# Altium schematic sheet OTHER_PARTS.SchDoc(OTHER_PARTS)
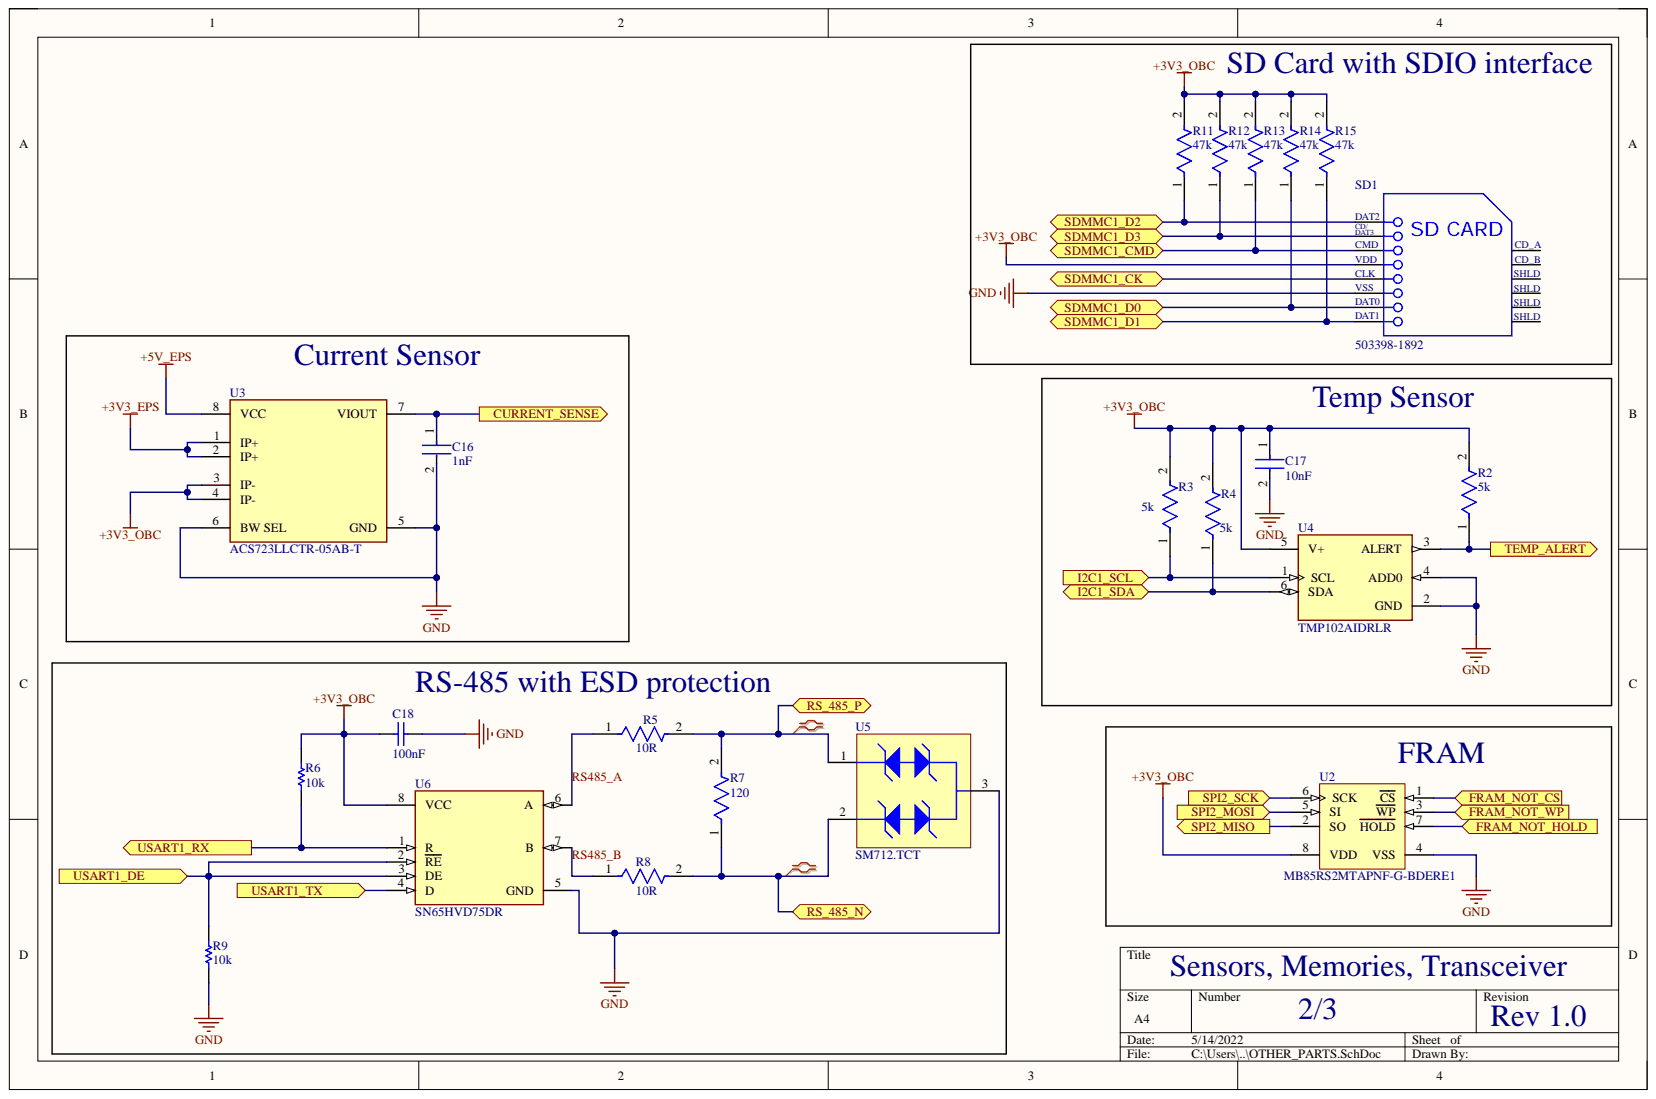
[diagram p0: OTHER_PARTS.SchDoc]

## Parts
C16  1nF  [FP-CC0402-MFG]
C17  10nF  [FP-CL165-IPC_C]
C18  100nF  [SAMS-C0603-2_M]
R2  5k  [FP-MCR01-MFG]
R3  5k  [FP-MCR01-MFG]
R4  5k  [FP-MCR01-MFG]
R5  10R  [FP-SR0805-MFG]
R6  10k  [RESC1608X55X25ML10T15]
R7  120  [FP-AC1206-MFG]
R8  10R  [FP-SR0805-MFG]
R9  10k  [RESC1608X55X25ML10T15]
R11  47k  [FP-MCR01-IPC_C]
R12  47k  [FP-MCR01-IPC_C]
R13  47k  [FP-MCR01-IPC_C]
R14  47k  [FP-MCR01-IPC_C]
R15  47k  [FP-MCR01-IPC_C]
SD1  503398-1892  [MOLX-503398-1892_V]
U2  MB85RS2MTAPNF-G-BDERE1  [CYP-S08.15_M]
U3  ACS723LLCTR-05AB-T  [FP-LC-8-MFG]
U4  TMP102AIDRLR  [FP-DRL0006A-MFG]
U5  SM712.TCT  [SMTC-SOT23-3_V]
U6  SN65HVD75DR  Case/Package: D  [FP-D0008A-MFG]

## Ports
CURRENT_SENSE, FRAM_NOT_CS, FRAM_NOT_HOLD, FRAM_NOT_WP, I2C1_SCL, I2C1_SDA, RS_485_N, RS_485_P, SDMMC1_CK, SDMMC1_CMD, SDMMC1_D0, SDMMC1_D1, SDMMC1_D2, SDMMC1_D3, SPI2_MISO, SPI2_MOSI, SPI2_SCK, TEMP_ALERT, USART1_DE, USART1_RX, USART1_TX

## Nets
+3V3_EPS: U3.1(IP+) U3.2(IP+)
+3V3_OBC: C17.1 C18.1 R2.2 R3.2 R4.2 R6.2 R11.2 R12.2 R13.2 R14.2 R15.2 SD1.4 U2.8(VDD) U3.3(IP-) U3.4(IP-) U4.5(V+) U6.8(VCC)
+5V_EPS: U3.8(VCC)
GND: C16.2 C17.2 C18.2 R9.1 SD1.6 U2.4(VSS) U3.5(GND) U3.6(BW_SEL) U4.2(GND) U4.4(ADD0) U5.3 U6.5(GND)
CURRENT_SENSE: C16.1 U3.7(VIOUT) ; port CURRENT_SENSE
FRAM_NOT_CS: U2.1(CS) ; port FRAM_NOT_CS
FRAM_NOT_HOLD: U2.7(HOLD) ; port FRAM_NOT_HOLD
FRAM_NOT_WP: U2.3(WP) ; port FRAM_NOT_WP
I2C1_SCL: R3.1 U4.1(SCL) ; port I2C1_SCL
I2C1_SDA: R4.1 U4.6(SDA) ; port I2C1_SDA
RS485_A: R5.1 U6.6(A)
RS485_B: R8.1 U6.7(B)
RS_485_N: R7.1 R8.2 U5.2 ; port RS_485_N
RS_485_P: R5.2 R7.2 U5.1 ; port RS_485_P
SDMMC1_CK: SD1.5 ; port SDMMC1_CK
SDMMC1_CMD: R13.1 SD1.3 ; port SDMMC1_CMD
SDMMC1_D0: R14.1 SD1.7 ; port SDMMC1_D0
SDMMC1_D1: R15.1 SD1.8 ; port SDMMC1_D1
SDMMC1_D2: R11.1 SD1.1(SD_CARD) ; port SDMMC1_D2
SDMMC1_D3: R12.1 SD1.2 ; port SDMMC1_D3
SPI2_MISO: U2.2(SO) ; port SPI2_MISO
SPI2_MOSI: U2.5(SI) ; port SPI2_MOSI
SPI2_SCK: U2.6(SCK) ; port SPI2_SCK
TEMP_ALERT: R2.1 U4.3(ALERT) ; port TEMP_ALERT
USART1_DE: R9.2 U6.2(RE) U6.3(DE) ; port USART1_DE
USART1_RX: R6.1 U6.1(R) ; port USART1_RX
USART1_TX: U6.4(D) ; port USART1_TX

Not connected: SD1 9 10 11 12 13 14

## Library data of the parts
C16 = CMP-2002-03059-2: CAP CER 1000PF 50V X7R 0402; Manufacturer: YAGEO; Manufacturer Part Number: CC0402KRX7R9BB102; Automotive Grade: NA; Capacitance: 1.0 nF; Material: Ceramic; Temperature Coefficient: 30ppm/°C; Tolerance: 0.1; Value: 1.0 nF; Automotive: No; Category: Cap; Component Kind: Standard; ComponentLink2Description: Datasheet; ComponentLink2URL: http://www.yageo.com/documents/recent/UPY-GP_NP0_16V-to-50V_16.pdf; Device Class L1: Passive Components; Device Class L2: Capacitors; Device Class L3: Ceramic Capacitors; Digikey Description: CAP CER 1000PF 50V X7R 0402; Footprint Url: http://www.yageo.com/exep/pages/download/literatures/UPY-C_GEN_24.pdf; Height: 0.55mm; Ipc Land Pattern Name: CAPC10050X50; Lead Free: Yes; Library Name: Altium Content Vault; Mouser Description: 0402 1000 pF 50 V ±10 % Tolerance X7R SMT Multilayer Ceramic Capacitor; Octopart: https://octopart.com/cc0402krx7r9bb102-yageo-192889; Package: CC0402; RoHS: TRUE; Temperature: -55°C to +125°C; Temperature Characteristic: X7R; Temperature Range High: +125°C; Temperature Range Low: -55°C; Voltage Rating: 50 V
C17 = CMP-13271-000387-1: Cap Ceramic 10nF 50V X7R ±10% SMD 0402 +125°C Paper T/R; Capacitance: 10nF; Case Code (Imperial): 0402; Case Code (Metric): 1005; Case/Package: 0402; Component Kind: Standard; Contact Plating: Tin; Depth: 0.5mm; Dielectric: X7R; Height: 0.5mm; Lead Free: Lead Free; Length: 1mm; Library Name: Altium Content Vault; Material: Ceramic; Max Operating Temperature: 125°C; Min Operating Temperature: -55°C; Mount: Surface Mount; Package Quantity: 1; Packaging: Tape and Reel; Radiation Hardening: No; Thickness: 0.022inch; Tolerance: 10%; Voltage: 50V; Voltage Rating: 50V; Voltage Rating (DC): 50V; Width: 0.02inch
C18 = CMP-2000-05332-1: Multi-layer Ceramic Capacitor, -55 to 125 degC, 2-Pin SMD (0603), RoHS, Tape and Reel; Manufacturer: Samsung Electro-Mechanics; Capacitance: 0.1µF; Dielectric: X7R; Rated Voltage: 50V; Tolerance (%): ±10%; Case Size/Dimension: 0603; Component Kind: Standard; Datasheet URL: https://datasheet.ciiva.com/29198/456651-da-01-en-vs-kondensator-0605-29198839.pdf; Datasheet Version: Nov-10; Library Name: Altium Content Vault; Manufacturer URL: http://www.samsungsem.com/global/index.jsp
R2-R4 = CMP-08839-006175-1: Thick Film Chip Resistor, 0402, 5.1kΩ, 1%, 100ppm/°C, 0.063W, 50V; Max Power Dissipation: 63mW; Resistance: 5.1kR; Temperature Coefficient: 400ppm/°C; Case Code (Imperial): 0402; Case Code (Metric): 1005; Case/Package: 0402; Component Kind: Standard; Construction: Rectangular; Depth: 0.5mm; Features: Automotive AEC-Q200; Height: 0.016inch; Lead Free: Lead Free; Length: 0.039inch; Library Name: Altium Content Vault; Max Operating Temperature: 155°C; Military Standard: Not; Min Operating Temperature: -55°C; Number of Terminations: 2; Package Quantity: 10000; Packaging: Tape and Reel; Resistor Type: Thick Film; RoHS Compliant: Yes; Series: MCR01; Tolerance: 1%; Voltage Rating (DC): 50V; Width: 0.02inch
R5 R8 = CMP-03412-003758-1: RES 10 OHM 1% 1/2W 0805; Manufacturer: Yageo; Manufacturer Part Number: SR0805FR-4710RL; Automotive Grade: Grade 0; Power Rating: 0.5W; Resistance: 10Ω; Tolerance: 0.01; Value: 10Ω; Voltage Rating: 150V; Automotive: Yes; Category: Res; Component Kind: Standard; ComponentLink2Description: Datasheet; ComponentLink2URL: http://www.yageo.com/documents/recent/PYu-SR_D_F%20tol_RoHS_L_3.pdf; Composition: Thick Film; Device Class L1: Passive Components; Device Class L2: Resistors; Device Class L3: Chip SMD Resistors; Digikey Description: RES 10 OHM 1% 1/2W 0805; Footprint Url: http://www.yageo.com/exep/pages/download/literatures/PYu-R_Mount_10.pdf; Height: 0.6mm; Ipc Land Pattern Name: RESC200125X50; Lead Free: Yes; Library Name: Altium Content Vault; Mouser Description: Res Surge Chip Thick Film 0805 10 Ohm 1% 1/2W ±200ppm/°C Molded Paper T/R; Octopart: https://octopart.com/sr0805fr-4710rl-yageo-91478096; Package: SR0805; RoHS: TRUE; Series: SR; Temperature: -55°C to +155°C; Temperature Range High: +155°C; Temperature Range Low: -55°C
R6 R9 = CMP-2000-05022-1: Resistor, 10 KOhm, +/- 5%, 100 mW, -55 to 155 degC, 0603 (1608 Metric), RoHS, Tape and Reel; Manufacturer: Yageo; Power Rating: 100mW; Resistance: 10kOhms; Temperature Coefficient: 100PPM/C; Voltage Rating: 50V; Case Code - in: 0603; Case Code - mm: 1608; Component Kind: Standard; Datasheet URL: http://www.farnell.com/datasheets/443971.pdf; Datasheet Version: Rev. 4, 04/2009; Library Name: Altium Content Vault; Manufacturer URL: http://www.yageo.com/; Operating Temperature Range: -55Cto+155C; Package Description: 2-Pin Surface Mount Device, Body 1.6 x 0.8 mm; Package Reference: 603; Packaging: Reel; Product: ThickFilmResistorsGeneralPurpose; Series: RC; Termination Style: SMD/SMT; Tolerance: 5%
R7 = CMP-03412-006306-1: RES SMD 120 OHM 1% 1/4W 1206; Manufacturer: Yageo; Manufacturer Part Number: AC1206FR-07120RL; Automotive Grade: Grade 0; Power Rating: 0.25W; Resistance: 120Ω; Tolerance: 0.01; Value: 120Ω; Voltage Rating: 200V; Automotive: Yes; Category: Res; Component Kind: Standard; ComponentLink2Description: Datasheet; ComponentLink2URL: http://www.yageo.com/NewPortal/yageodocoutput?fileName=/pdf/R-Chip/PYu-AC_51_RoHS_L_6.pdf; Composition: Thick Film; Device Class L1: Passive Components; Device Class L2: Resistors; Device Class L3: Chip SMD Resistors; Digikey Description: RES SMD 120 OHM 1% 1/4W 1206; Footprint Url: http://www.yageo.com/exep/pages/download/literatures/PYu-R_Mount_10.pdf; Height: 0.65mm; Ipc Land Pattern Name: RESC310160X55; Lead Free: Yes; Library Name: Altium Content Vault; Mouser Description: Thick Film Resistors - SMD 1/4W 120ohm 1% AEC-Q200; Octopart: https://octopart.com/ac1206fr-07120rl-yageo-30511031; Package: AC1206; RoHS: TRUE; Series: AC; Temperature: -55°C to +155°C; Temperature Range High: +155°C; Temperature Range Low: -55°C
R11-R15 = CMP-08839-009136-1: Thick Film Chip Resistor, 0402, 47kΩ, 1%, 100ppm/°C, 0.063W, 50V; Max Power Dissipation: 63mW; Resistance: 47kR; Temperature Coefficient: 400ppm/°C; Case Code (Imperial): 0402; Case Code (Metric): 1005; Case/Package: 0402; Component Kind: Standard; Construction: Rectangular; Depth: 0.5mm; Features: Automotive AEC-Q200; Height: 0.016inch; Lead Free: Lead Free; Length: 0.039inch; Library Name: Altium Content Vault; Max Operating Temperature: 155°C; Military Standard: Not; Min Operating Temperature: -55°C; Mount: Surface Mount; Number of Terminations: 2; Package Quantity: 1; Packaging: Tape and Reel; Power Rating: 63mW; Resistor Type: Thick Film; RoHS Compliant: Yes; Series: MCR01; Size Code: 0402; Tolerance: 1%; Voltage Rating (DC): 50V; Width: 0.02inch
SD1 = CMP-2000-05621-1: Micro SD Connector, Pitch 1.1 mm, 8 Position, Height 1.4 mm, -25 to 85 degC, RoHS, Tape and Reel; Brand: Molex; Current Rating: 500mA; Voltage Rating: 10VAC/DC; Component Kind: Standard; Datasheet URL: http://www.molex.com/pdm_docs/sd/5033981892_sd.pdf; Datasheet Version: Rev. E, 04/2006; Factory Pack Quantity: 2400; Library Name: Altium Content Vault; Manufacturer: Molex; Manufacturer URL: http://www.molex.com/; Mounting Technology: SurfaceMount; Package Description: Micro SD Connector, Pitch 1.1 mm, 8 Position, Height 1.4 mm; Package Reference: 503398-1892; Packaging: Reel; Product Category: MemoryCardConnectors; RoHS: Details; Series: 503398
U2 = CMP-1640-00001-1: 1-Mbit Serial F-RAM, 2 to 3.6 V, -40 to 85 degC, 8-Pin SOIC (S08.15), RoHS, Tube; Generic Part Number: FM25V10; PartNumber: FM25V10-G; Access Time: 18 ns; Memory Size: 1 Mbits; Supply Voltage Max: 3.6 V; Supply Voltage Min: 2 V; Code_JEDEC: MS-012; Component Kind: Standard; ComponentLink1Description: Manufacturer URL; ComponentLink1URL: http://www.cypress.com/; ComponentLink2Description: Datasheet; ComponentLink2URL: http://www.cypress.com/file/41691/download; ComponentLink3Description: Package Specification; ComponentLink3URL: http://www.mill-max.com/assets/pdfs/metric/007M.PDF; DatasheetVersion: Rev. *F; Library Name: Altium Content Vault; Mounting Technology: Surface Mount; PackageDescription: 8-Pin SOIC, Body 4.9 x 3.9 mm, Pitch 1.27 mm; PackageVersion: Rev. *D, 12/2009; Packing: Tube; RoHS: TRUE; Temperature Max: 85 degC; Temperature Min: -40 degC
U3 = CMP-82923-000114-1: SENSOR CURRENT HALL 5A AC/DC; Accuracy: 3%; Frequency: 80kHz; Linearity: 1%; Max Supply Current: 14mA; Number of Channels: 1; Polarity: Bidirectional; Response Time: 5us; Sensitivity: 400mV/A; Case/Package: SOIC; Component Kind: Standard; Current Sensing: 5A; Library Name: Altium Content Vault; Max Operating Temperature: 150°C; Min Operating Temperature: -40°C; Mount: Surface Mount; Number of Pins: 8; Packaging: Tape and Reel; RoHS Compliant: Yes
U4 = CMP-04948-000029-1: SENSOR DIGITAL -40C-125C SOT563; Interface: I2C; Max Supply Voltage: 3.6V; Min Supply Voltage: 1.4V; Nominal Supply Current: 85uA; Number of Bits: 12; Operating Supply Current: 1uA; Output Current: 3mA; Quiescent Current: 10uA; Resolution: 12b; Case/Package: SOT-563; Component Kind: Standard; Lead Free: Lead Free; Library Name: Altium Content Vault; Max Operating Temperature: 125°C; Max Supply Voltage (DC): 3.6V; Min Operating Temperature: -40°C; Min Supply Voltage (DC): 1.4V; Mount: Surface Mount; Number of Pins: 6; Output Type: Digital; Package Quantity: 4000; Packaging: Tape and Reel; Radiation Hardening: No; REACH SVHC: No SVHC; RoHS Compliant: Yes; Series: -; Supply Current: 85uA; Weight: 9.9E-05oz
U5 = CMP-2000-05357-1: Asymmetrical TVS Diode for Extended Common-Mode RS-485, 400 W, -55 to 125 degC, 3-Pin SOT23, RoHS, Tape and Reel; Manufacturer: Semtech; Clamping Voltage: 26V/12V; Configuration: Dual; Direction Type: Bi-Directional; Leakage Current: 1uA/20uA; Leakage Current (Max): 0.02uA; Number of Elements: 2; Peak Pulse Current: 17A; Peak Pulse Power Dissipation: 400W; Product Depth (mm): 1.3mm; Product Height (mm): 0.95mm; Product Length (mm): 2.9mm; Rad Hardened: No; Reverse Breakdown Voltage: 13.3V; Reverse Stand-off Voltage: 12V; Test Current (It): 1mA; Working Voltage: 12V/7V; Component Kind: Standard; Datasheet URL: https://datasheet.ciiva.com/18065/getdatasheetpartid-110620-18065348.pdf; Datasheet Version: Nov-04; Library Name: Altium Content Vault; Manufacturer URL: http://www.semtech.com/; Mounting: SurfaceMount; Mounting Style: SurfaceMount; Operating Temp Range: -55Cto125C; Operating Temperature Classification: Military; Operating Temperature Max Deg. C: 125C; Operating Temperature Min Deg. C: -55C; Package / Case: SOT-23; Package Description: 3-Pin Small Outline Transistor, Body 2.9 x 1.3 mm, Pitch 0.95 mm; Package Reference: SOT23-3; Packaging: TapeandReel; Pin Count: 3; Polarity: Bi-Directional; Suppressor Type: TVS
U6 = CMP-0694-00003-3: IC TRANSCEIVER HALF 1/1 8SOIC; Data Rate: 20Mbps; Interface: RS-422; Max Supply Voltage: 3.6V; Min Supply Voltage: 3V; Nominal Supply Current: 950uA; Number of Drivers: 1; Operating Supply Voltage: 3.3V; Receiver Hysteresis: 80mV; Simplex/Duplex: Half Duplex; Component Kind: Standard; Contact Plating: Gold; Lead Free: Lead Free; Library Name: Altium Content Vault; Logic Function: Transceiver; Max Operating Temperature: 125°C; Min Operating Temperature: -40°C; Number of Pins: 8; Number of Receivers: 1; Number of Transceivers: 1; Number of Transmitters: 1; Package Quantity: 2500; Packaging: Tape and Reel; Radiation Hardening: No; REACH SVHC: No SVHC; RoHS Compliant: Yes; Series: -; Supply Current: 950uA
